# Altium schematic sheet Sheet1.SchDoc
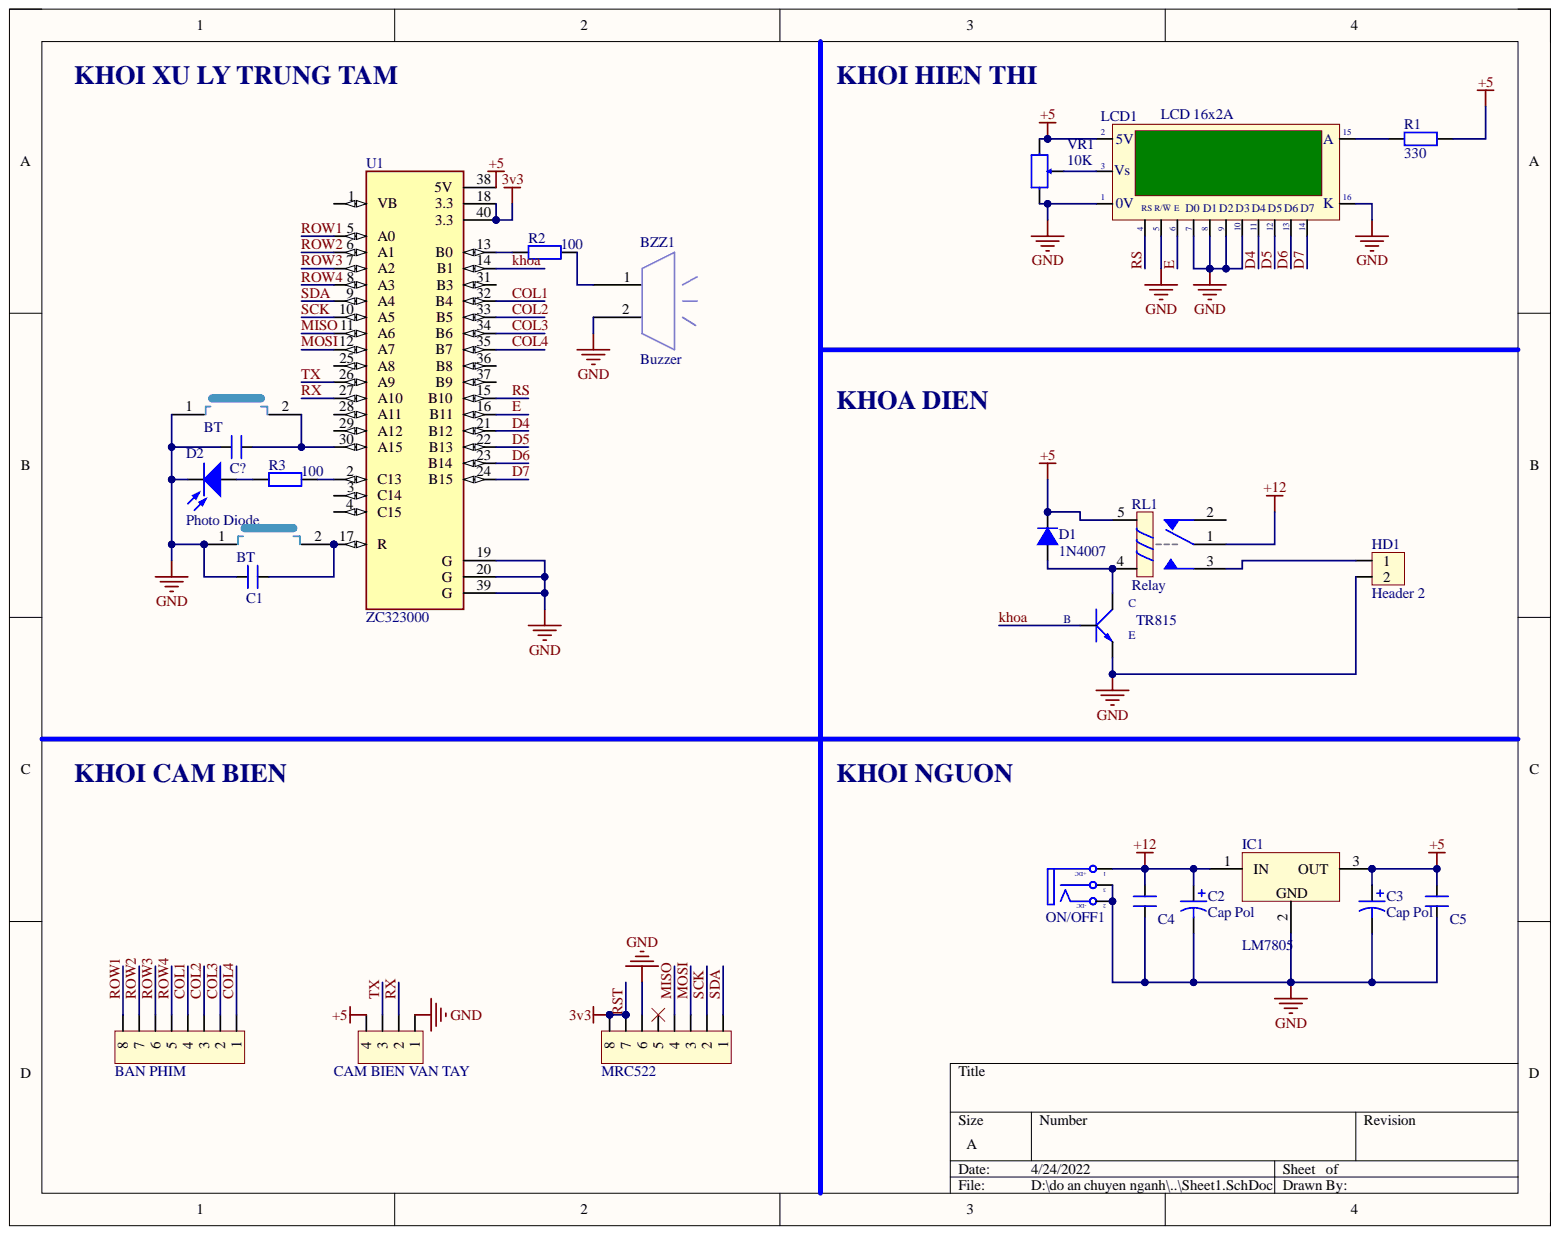
[diagram p0: Sheet1.SchDoc]

## Parts
BAN_PHIM  JP8  [CON8]  (value not drawn)
BT1  BT  [SW4]
BT?  BT  [SW4]
BZZ1  Buzzer  [buzzer 5V]
C1  CAP gom  [CN1]  (value not drawn)
C2  Cap Pol  [C3MM]
C3  Cap Pol  [C3MM]
C4  CAP gom  [CN1]  (value not drawn)
C5  CAP gom  [CN1]  (value not drawn)
C?  CAP gom  [CN1]  (value not drawn)
CAM_BIEN_VAN_TAY  JP4  [CON4]  (value not drawn)
D1  1N4007  [D1A]
D2  Photo Diode  [LED-5]
HD1  Header 2  [HED2]
IC1  LM7805  [QTO-220]
LCD1  LCD 16x2A  [LCD16X2]
MRC522  JP8  [CON8]  (value not drawn)
ON/OFF1  POWER  [DC-IN]  (value not drawn)
R1  330  [DIEN TRO 1/4 W]
R2  100  [DIEN TRO 1/4 W]
R3  100  [DIEN TRO 1/4 W]
RL1  Relay  [RL_SPDT_10A]
STA.TR1  TR815  [QTO-92]
U1  ZC323000  [MODULE_ZC323000]
VR1  10K  [VR]

## Nets
+5: C3.1 C5.2 CAM_BIEN_VAN_TAY.4 D1.2 IC1.3 LCD1.2(5V) R1.2 RL1.5 U1.38(5V) VR1.1
+12: C2.1 C4.2 IC1.1 ON/OFF1.1 RL1.1
GND: BT1.1 BT?.1 BZZ1.2 C1.1 C2.2 C3.2 C4.1 C5.1 C?.1 CAM_BIEN_VAN_TAY.1 D2.2 HD1.2 IC1.2 LCD1.1(0V) LCD1.5 LCD1.7(D0) LCD1.8(D1) LCD1.9(D2) LCD1.10(D3) LCD1.16(K) MRC522.6 ON/OFF1.2 ON/OFF1.3 STA.TR1.1 U1.19(G) U1.20(G) U1.39(G) VR1.3
COL1: BAN_PHIM.4 U1.32(B4)
COL2: BAN_PHIM.3 U1.33(B5)
COL3: BAN_PHIM.2 U1.34(B6)
COL4: BAN_PHIM.1 U1.35(B7)
D4: LCD1.11(D4) U1.21(B12)
D5: LCD1.12(D5) U1.22(B13)
D6: LCD1.13(D6) U1.23(B14)
D7: LCD1.14(D7) U1.24(B15)
E: LCD1.6(E) U1.16(B11)
khoa: STA.TR1.3 U1.14(B1)
MISO: MRC522.4 U1.11(A6)
MOSI: MRC522.3 U1.12(A7)
ROW1: BAN_PHIM.8 U1.5(A0)
ROW2: BAN_PHIM.7 U1.6(A1)
ROW3: BAN_PHIM.6 U1.7(A2)
ROW4: BAN_PHIM.5 U1.8(A3)
RS: LCD1.4(RS) U1.15(B10)
RST: MRC522.7 MRC522.8 U1.18(3.3) U1.40(3.3)
RX: CAM_BIEN_VAN_TAY.2 U1.27(A10)
SCK: MRC522.2 U1.10(A5)
SDA: MRC522.1 U1.9(A4)
TX: CAM_BIEN_VAN_TAY.3 U1.26(A9)
NetBT1_2: BT1.2 C1.2 U1.17(R)
NetBT?_2: BT?.2 C?.2 U1.30(A15)
NetBZZ1_1: BZZ1.1 R2.2
NetD1_1: D1.1 RL1.4 STA.TR1.2
NetD2_1: D2.1 R3.1
NetHD1_1: HD1.1 RL1.3
NetLCD1_3: LCD1.3(Vs) VR1.2
NetLCD1_15: LCD1.15(A) R1.1
NetR2_1: R2.1 U1.13(B0)
NetR3_2: R3.2 U1.2(C13)

Not connected: MRC522 5; RL1 2; U1 1(VB) 3(C14) 4(C15) 25(A8) 28(A11) 29(A12) 31(B3) 36(B8) 37(B9)

## Library data of the parts
BAN_PHIM MRC522 = Con8: Connector 8; Component Kind: Standard; Value: Connector 8; Library Name: syhaunguyen.IntLib
BT1 BT? = BUTTON: Component Kind: Standard; Library Name: My library altium.IntLib
C2 C3 = Cap Pol: Polarized Capacitor (Axial); Component Kind: Standard; LatestRevisionDate: 17-Jul-2002; LatestRevisionNote: Re-released for DXP Platform.; Library Name: My library altium.IntLib; PackageReference: POLAR0.8; Published: 8-Jun-2000; Publisher: Altium Limited
CAM_BIEN_VAN_TAY = Con4: Connector 4; Component Kind: Standard; Value: Connector 4; Library Name: syhaunguyen.IntLib
D1 = D1A: Diode 1A; Component Kind: Standard; Value: 1N4007; Library Name: syhaunguyen.IntLib
D2 = Photo Diode: Photosensitive Diode; Component Kind: Standard; Library Name: My library altium.IntLib
HD1 = Hed2: Header 2 (Green); Component Kind: Standard; Value: Header 2; Library Name: syhaunguyen.IntLib
IC1 = LM78xx: On ap +, from + 5 to + 24VDC; Component Kind: Standard; Library Name: My library altium.IntLib; Value: LM7805
LCD1 = LCD16x2A: LCD dipslay 16 char, 2 line; Component Kind: Standard; Library Name: My Library.SchLib; Value: LCD16x2A
ON/OFF1 = DCIN: Component Kind: Standard; Library Name: My library altium.IntLib
R1-R3 = Res 1/4 W: Resistor; Value: R; Component Kind: Standard; LatestRevisionDate: 17-Jul-2002; LatestRevisionNote: Re-released for DXP Platform.; Library Name: My Library.SchLib; PackageReference: AXIAL-0.4; Published: 8-Jun-2000; Signal Integrity: Res; Simulation: RESISTOR; Publisher: Altium Limited
RL1 = Relay SRD: Relay SPDT 5 Pin; Value: Relay SPDT, 10A, 12V; Component Kind: Standard; Library Name: My library altium.IntLib
STA.TR1 = C1815: NPN 600mW, ECB; Value: C1815; Component Kind: Standard; Library Name: My library altium.IntLib
U1 = ZC323000: STM32F103C8T6 ARM STM32 Minimum System Development Board Module For Arduino; MF: YKS; Availability: Unavailable; Component Kind: Standard; Library Name: stm32 blue pill.IntLib; MP: ZC323000; Package: None; Price: None; SnapEDA_Link: https://www.snapeda.com/parts/ZC323000/YKS/view-part/725364/?ref=snap
VR1 = VR: Var Resistor; Value: VR 10K; Component Kind: Standard; Library Name: My Library.SchLib
BZZ1 = Buzzer: Component Kind: Standard; Library Name: My library altium.IntLib
C1 C4 C5 C? = CAP gom: Component Kind: Standard; Library Name: My library altium.IntLib
